# Altium schematic sheet Sheet2.SchDoc(Sheet2)
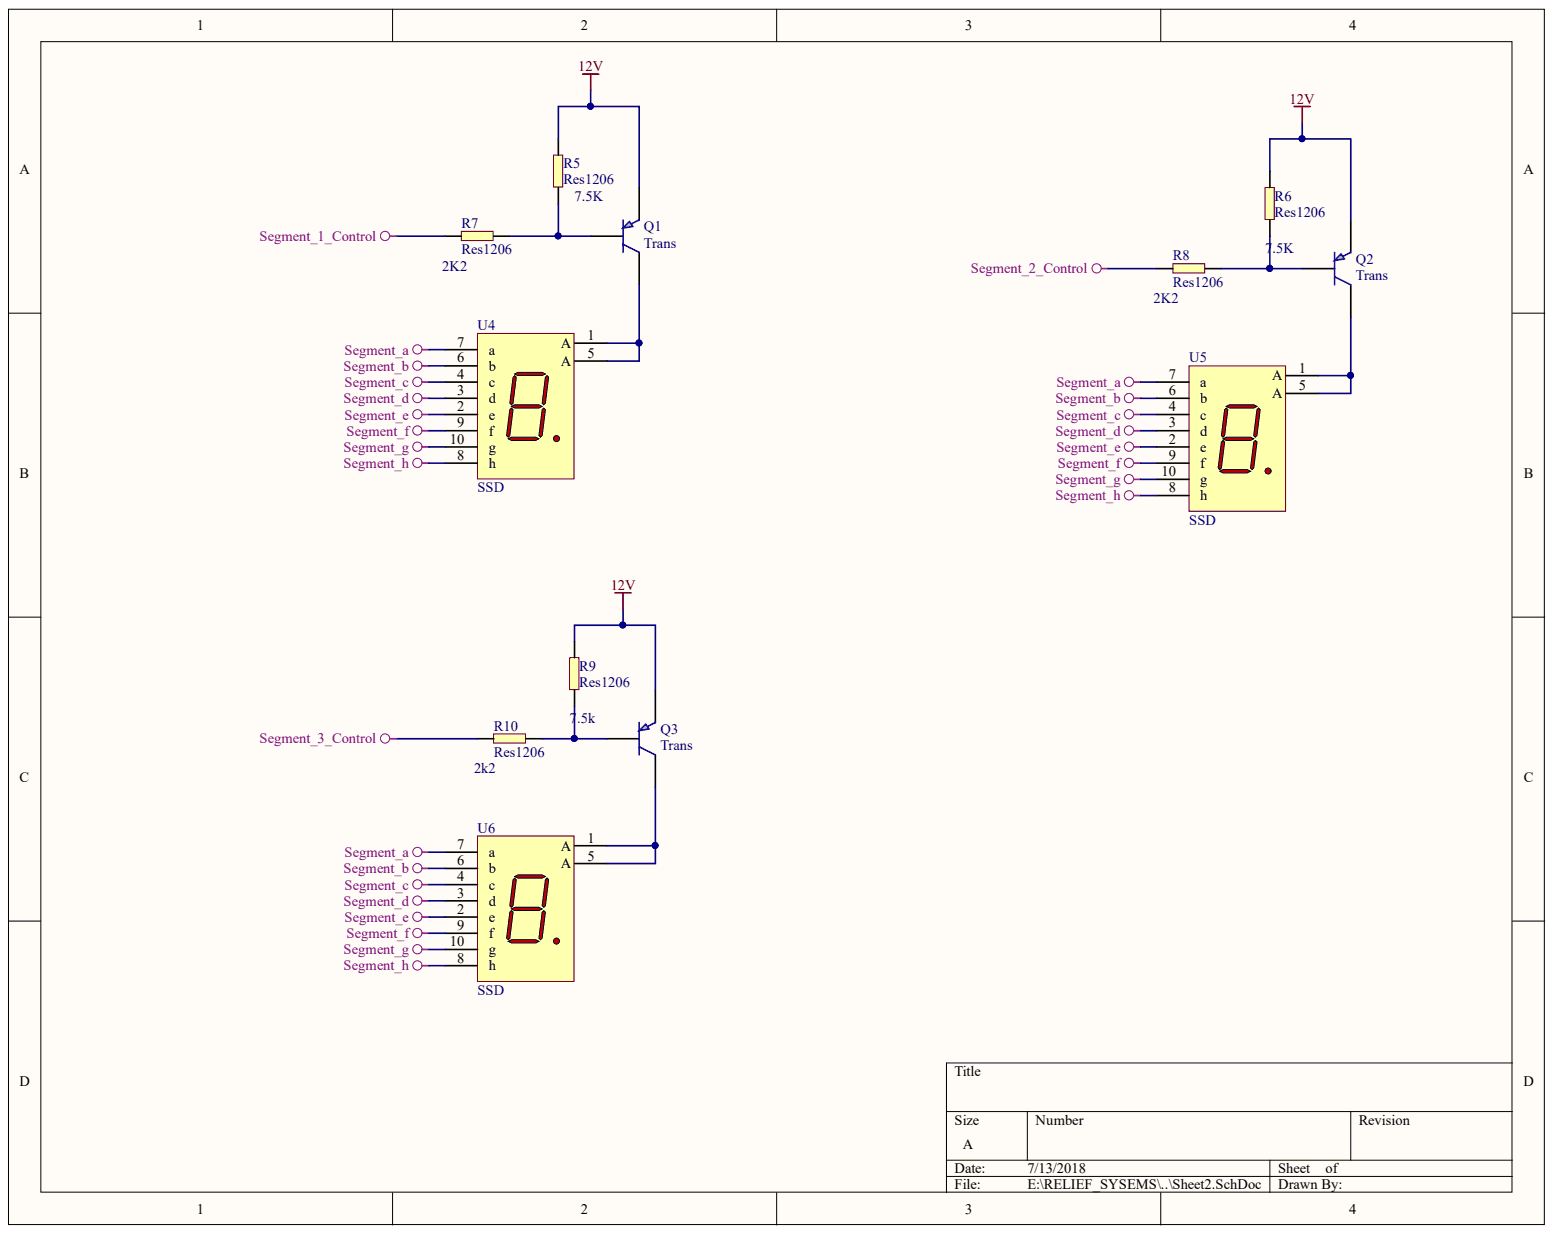
[diagram p0: Sheet2.SchDoc]

## Parts
Q1  Trans  [2N6107]
Q2  Trans  [2N6107]
Q3  Trans  [2N6107]
R5  Res1206  value 7.5K  [1206]
R6  Res1206  value 7.5K  [1206]
R7  Res1206  value 2K2  [1206]
R8  Res1206  value 2K2  [1206]
R9  Res1206  value 7.5k  [1206]
R10  Res1206  value 2k2  [1206]
U4  SSD  [SP1802_SSD]
U5  SSD  [SP1802_SSD]
U6  SSD  [SP1802_SSD]

## Nets
12V: Q1.3 Q2.3 Q3.3 R5.1 R6.2 R9.2
SEGMENT_1_CONTROL: R7.2
SEGMENT_2_CONTROL: R8.1
SEGMENT_3_CONTROL: R10.1
SEGMENT_A: U4.7(a) U5.7(a) U6.7(a)
SEGMENT_B: U4.6(b) U5.6(b) U6.6(b)
SEGMENT_C: U4.4(c) U5.4(c) U6.4(c)
SEGMENT_D: U4.3(d) U5.3(d) U6.3(d)
SEGMENT_E: U4.2(e) U5.2(e) U6.2(e)
SEGMENT_F: U4.9(f) U5.9(f) U6.9(f)
SEGMENT_G: U4.10(g) U5.10(g) U6.10(g)
SEGMENT_H: U4.8(h) U5.8(h) U6.8(h)
NetQ1_1: Q1.1 R5.2 R7.1
NetQ1_2: Q1.2 U4.1(A) U4.5(A)
NetQ2_1: Q2.1 R6.1 R8.2
NetQ2_2: Q2.2 U5.1(A) U5.5(A)
NetQ3_1: Q3.1 R9.1 R10.2
NetQ3_2: Q3.2 U6.1(A) U6.5(A)

## Library data of the parts
Q1-Q3 = 2N6107: pnp transistor; Component Kind: Standard; Library Name: VIJAYIntegrated_Library.IntLib
R5 = Res1206: Value: 7.5K; Component Kind: Standard; Description: Res1206; Library Name: Dinesh_New_Integrated_Library.IntLib
U4-U6 = SP1802: SSD 48MM; Component Kind: Standard; Library Name: VIJAYIntegrated_Library.IntLib
R6-R10 = Res1206: Component Kind: Standard; Description: Res1206; Library Name: Dinesh_New_Integrated_Library.IntLib
